# Altium schematic sheet pyBase.SchDoc(pyBase)
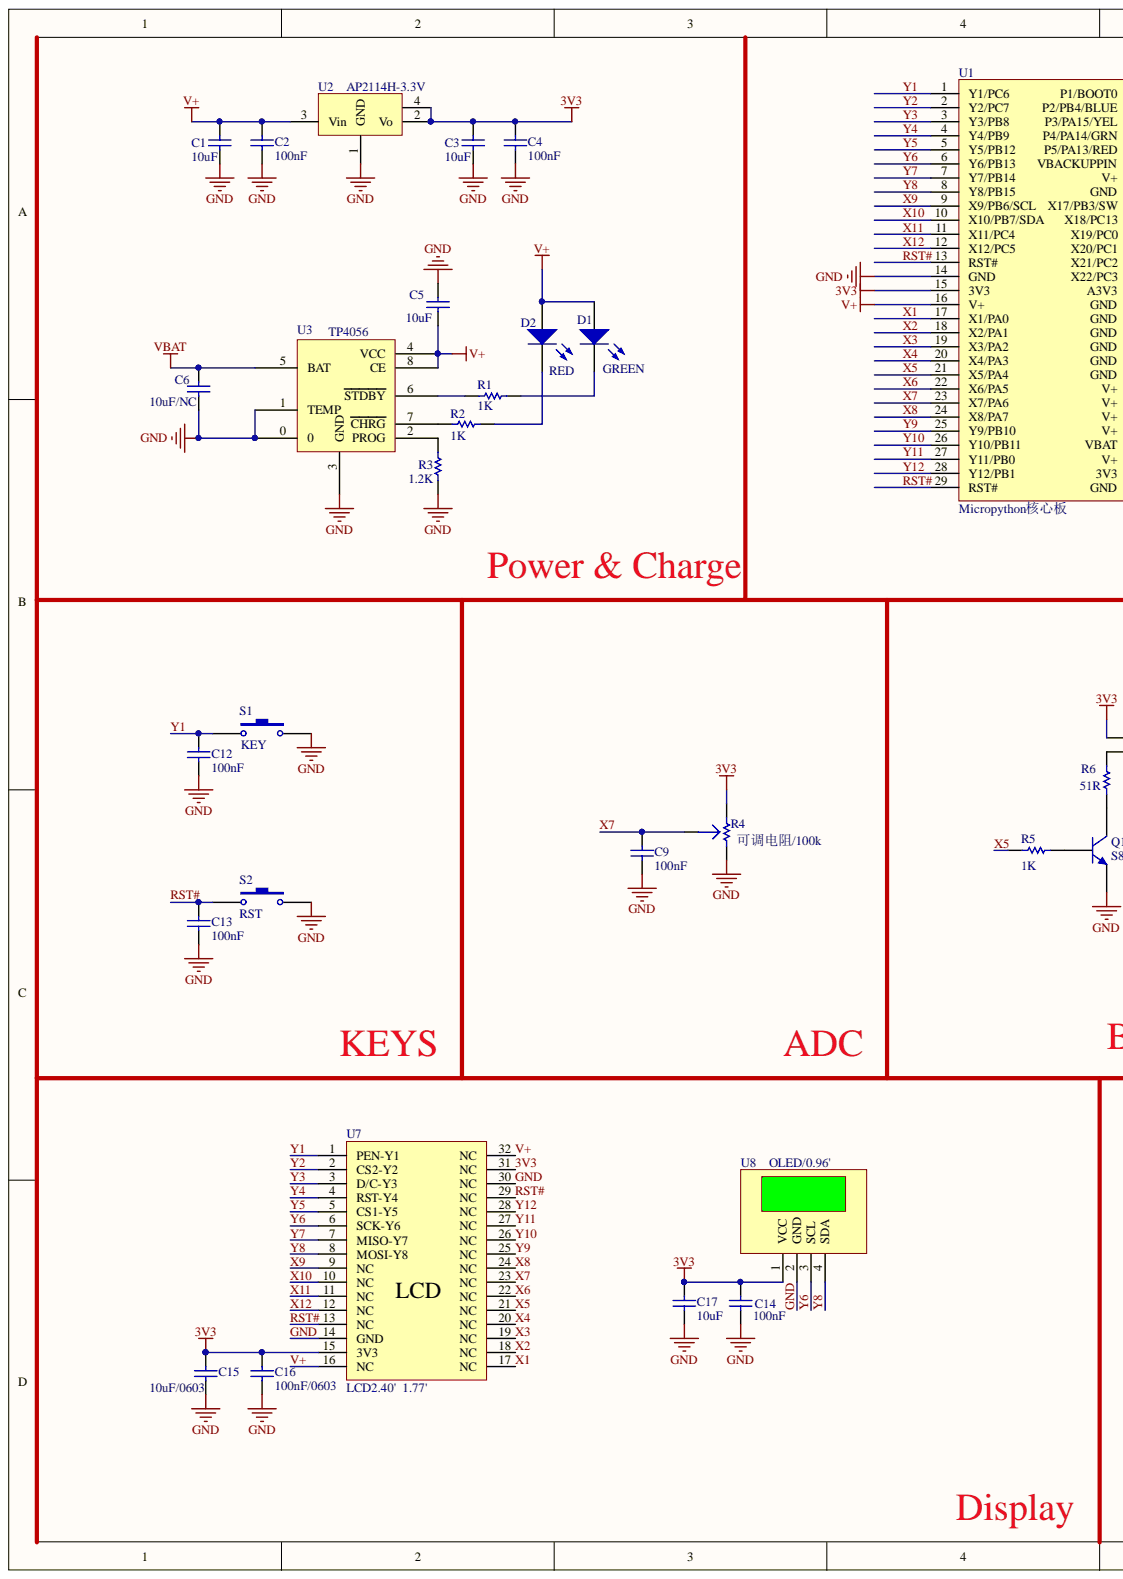
[diagram p0: pyBase.SchDoc, left]
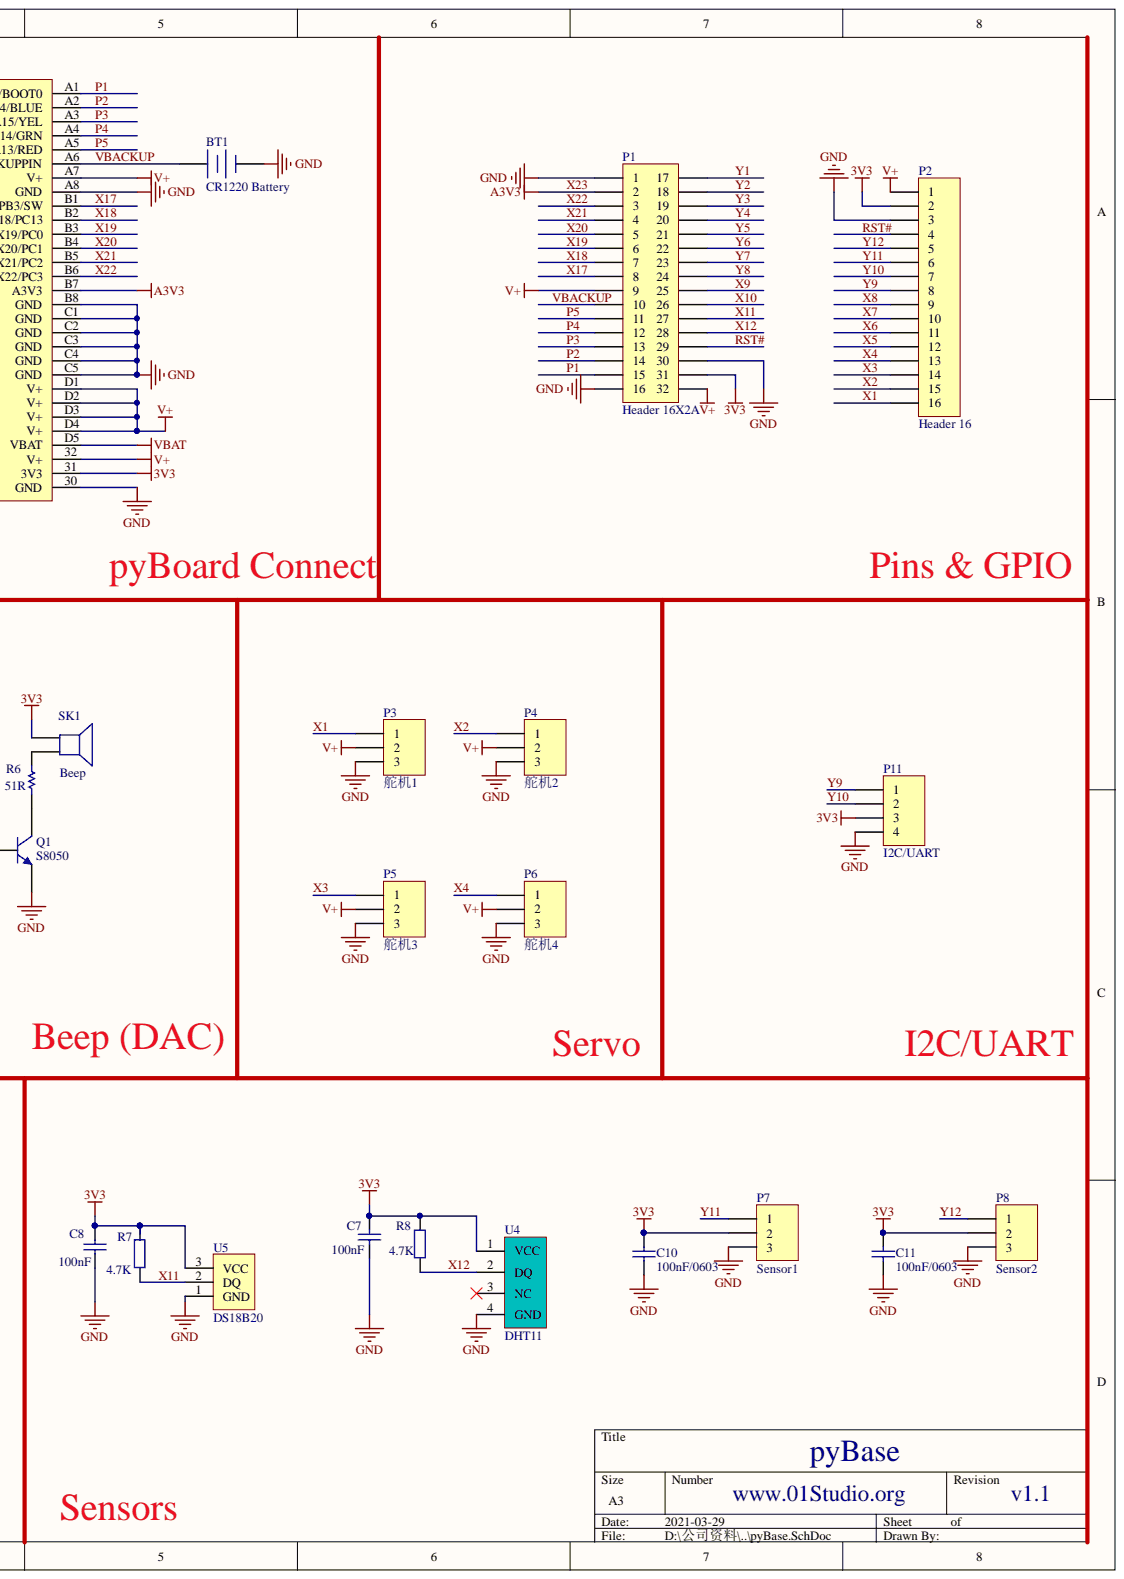
[diagram p1: pyBase.SchDoc, right]

## Parts
BT1  CR1220 Battery  [CR1220贴片电池座]
C1  10uF  [C0603]
C2  100nF  [C0603]
C3  10uF  [C0603]
C4  100nF  [C0603]
C5  10uF  [C0603]
C6  10uF/NC  [C0603]
C7  100nF  [C0603]
C8  100nF  [C0603]
C9  100nF  [C0603]
C10  100nF/0603  [C0603]
C11  100nF/0603  [C0603]
C12  100nF  [C0603]
C13  100nF  [C0603]
C14  100nF  [C0603]
C15  10uF/0603  [C0603]
C16  100nF/0603  [C0603]
C17  10uF  [C0603]
D1  GREEN  [D0603]
D2  RED  [D0603]
P1  Header 16X2A  [HDR2X16_CEN]
P2  Header 16  [HDR1X16]
P3  舵机1  [HDR1X3]
P4  舵机2  [HDR1X3]
P5  舵机3  [HDR1X3]
P6  舵机4  [HDR1X3]
P7  Sensor1  [XH2.54-3P-SMT]
P8  Sensor2  [XH2.54-3P-SMT]
P11  I2C/UART  [XH2.54-4P_SMT]
Q1  S8050  [SOT-23]
R1  1K  [R0603]
R2  1K  [R0603]
R3  1.2K  [R0603]
R4  可调电阻/100k  [电位器]
R5  1K  [R0603]
R6  51R  [R0603]
R7  value 4.7K  [R0603]
R8  value 4.7K  [R0603]
S1  KEY  [贴片大按键]
S2  RST  [贴片大按键]
SK1  Beep  [蜂鸣器9042]
U1  Micropython核心板  [MicroPython核心板]
U2  AP2114H-3.3V  [AMS1117]
U3  TP4056  [TP4056]
U4  DHT11  [DHT11-直立]
U5  DS18B20  [DS18B20]
U7  LCD2.40'  1.77'  [LCD 2.4‘ MicroPython]
U8  OLED/0.96'  [OLED 0.96']

## Nets
VBAT: C6.1 U1.D5(VBAT) U3.5(BAT)
3V3: C3.1 C4.2 C7.2 C8.2 C10.2 C11.2 C14.2 C15.1 C16.2 C17.1 P1.31 P2.2 P7.2 P8.2 P11.3 R4.1 R7.2 R8.2 SK1.1 U1.15(3V3) U1.31(3V3) U2.2(Vo) U2.4 U4.1(VCC) U5.3 U7.15(3V3) U7.31(NC) U8.1(VCC)
GND: BT1.2 C1.2 C2.1 C3.2 C4.1 C5.1 C6.2 C7.1 C8.1 C9.1 C10.1 C11.1 C12.1 C13.1 C14.1 C15.2 C16.1 C17.2 P1.1 P1.16 P1.30 P2.3 P3.3 P4.3 P5.3 P6.3 P7.3 P8.3 P11.4 Q1.2 R3.2 R4.3 S1.2 S2.2 U1.14(GND) U1.30(GND) U1.A8(GND) U1.B8(GND) U1.C1(GND) U1.C2(GND) U1.C3(GND) U1.C4(GND) U1.C5(GND) U2.1(GND) U3.0 U3.1(TEMP) U3.3(GND) U4.4(GND) U5.1 U7.14(GND) U7.30(NC) U8.2(GND)
P1: P1.15 U1.A1(P1/BOOT0)
P2: P1.14 U1.A2(P2/PB4/BLUE)
P3: P1.13 U1.A3(P3/PA15/YEL)
P4: P1.12 U1.A4(P4/PA14/GRN)
P5: P1.11 U1.A5(P5/PA13/RED)
RST#: C13.2 P1.29 P2.4 S2.1 U1.13(RST#) U1.29(RST#) U7.13(NC) U7.29(NC)
V+: C1.1 C2.2 C5.2 D1.1 D2.1 P1.9 P1.32 P2.1 P3.2 P4.2 P5.2 P6.2 U1.16(V+) U1.32(V+) U1.A7(V+) U1.D1(V+) U1.D2(V+) U1.D3(V+) U1.D4(V+) U2.3(Vin) U3.4(VCC) U3.8(CE) U7.16(NC) U7.32(NC)
VBACKUP: BT1.1 P1.10 U1.A6(VBACKUPPIN)
X1: P2.16 P3.1 U1.17(X1/PA0) U7.17(NC)
X2: P2.15 P4.1 U1.18(X2/PA1) U7.18(NC)
X3: P2.14 P5.1 U1.19(X3/PA2) U7.19(NC)
X4: P2.13 P6.1 U1.20(X4/PA3) U7.20(NC)
X5: P2.12 R5.1 U1.21(X5/PA4) U7.21(NC)
X6: P2.11 U1.22(X6/PA5) U7.22(NC)
X7: C9.2 P2.10 R4.2 U1.23(X7/PA6) U7.23(NC)
X8: P2.9 U1.24(X8/PA7) U7.24(NC)
X9: P1.25 U1.9(X9/PB6/SCL) U7.9(NC)
X10: P1.26 U1.10(X10/PB7/SDA) U7.10(NC)
X11: P1.27 R7.1 U1.11(X11/PC4) U5.2 U7.11(NC)
X12: P1.28 R8.1 U1.12(X12/PC5) U4.2(DQ) U7.12(NC)
X17: P1.8 U1.B1(X17/PB3/SW)
X18: P1.7 U1.B2(X18/PC13)
X19: P1.6 U1.B3(X19/PC0)
X20: P1.5 U1.B4(X20/PC1)
X21: P1.4 U1.B5(X21/PC2)
X22: P1.3 U1.B6(X22/PC3)
X23: P1.2 U1.B7(A3V3)
Y1: C12.2 P1.17 S1.1 U1.1(Y1/PC6) U7.1(PEN-Y1)
Y2: P1.18 U1.2(Y2/PC7) U7.2(CS2-Y2)
Y3: P1.19 U1.3(Y3/PB8) U7.3(D/C-Y3)
Y4: P1.20 U1.4(Y4/PB9) U7.4(RST-Y4)
Y5: P1.21 U1.5(Y5/PB12) U7.5(CS1-Y5)
Y6: P1.22 U1.6(Y6/PB13) U7.6(SCK-Y6) U8.3(SCL)
Y7: P1.23 U1.7(Y7/PB14) U7.7(MISO-Y7)
Y8: P1.24 U1.8(Y8/PB15) U7.8(MOSI-Y8) U8.4(SDA)
Y9: P2.8 P11.1 U1.25(Y9/PB10) U7.25(NC)
Y10: P2.7 P11.2 U1.26(Y10/PB11) U7.26(NC)
Y11: P2.6 P7.1 U1.27(Y11/PB0) U7.27(NC)
Y12: P2.5 P8.1 U1.28(Y12/PB1) U7.28(NC)
NetD1_2: D1.2 R1.1
NetD2_2: D2.2 R2.1
NetQ1_1: Q1.1 R5.2
NetQ1_3: Q1.3 R6.1
NetR1_2: R1.2 U3.6(STDBY)
NetR2_2: R2.2 U3.7(CHRG)
NetR3_1: R3.1 U3.2(PROG)
NetR6_2: R6.2 SK1.2

Not connected: U4 3(NC)

## Library data of the parts
BT1 = Battery: Library Name: WeBee.SCHLIB; Component Kind: Standard
C1 C3 C5 C6 C15 C17 = Cap Semi: Capacitor (Semiconductor SIM Model); Simulation: CAP; Signal Integrity: Cap Semi; Published: 8-Jun-2000; PackageReference: C1206; Library Name: Miscellaneous Devices.IntLib; LatestRevisionNote: Re-released for DXP Platform.; LatestRevisionDate: 17-Jul-2002; Component Kind: Standard; Publisher: Altium Limited
C2 C4 C7-C14 C16 = Cap: Capacitor; Value: 100pF; Simulation: CAP; Signal Integrity: Cap; Published: 8-Jun-2000; PackageReference: RAD-0.3; Library Name: Miscellaneous Devices.IntLib; LatestRevisionNote: Re-released for DXP Platform.; LatestRevisionDate: 17-Jul-2002; Component Kind: Standard; Publisher: Altium Limited
D1 D2 = LED0: Typical INFRARED GaAs LED; Simulation: LED0; Published: 8-Jun-2000; PackageReference: LED-0; Library Name: Miscellaneous Devices.IntLib; LatestRevisionNote: Mech Layer 1 removed.; LatestRevisionDate: 08-Jul-2005; Component Kind: Standard; Publisher: Altium Limited
P1 = Header 16X2A: Header, 16-Pin, Dual row; Signal Integrity: Connector; Library Name: Miscellaneous Connectors.IntLib; LatestRevisionNote: Re-released for DXP Platform.; LatestRevisionDate: 17-Jul-2002; Component Kind: Standard; Publisher: Altium Limited
P2 = Header 16: Header, 16-Pin; Signal Integrity: Connector; Library Name: Miscellaneous Connectors.IntLib; LatestRevisionNote: Re-released for DXP Platform.; LatestRevisionDate: 17-Jul-2002; Component Kind: Standard; Publisher: Altium Limited
P3-P8 = Header 3: Header, 3-Pin; Signal Integrity: Connector; Library Name: Miscellaneous Connectors.IntLib; LatestRevisionNote: Re-released for DXP Platform.; LatestRevisionDate: 17-Jul-2002; Component Kind: Standard; Publisher: Altium Limited
P11 = Header 4: Header, 4-Pin; Signal Integrity: Connector; Library Name: Miscellaneous Connectors.IntLib; LatestRevisionNote: Re-released for DXP Platform.; LatestRevisionDate: 17-Jul-2002; Component Kind: Standard; Publisher: Altium Limited
R1-R3 R5 = Res1: Resistor; Simulation: RESISTOR; Signal Integrity: Res; Published: 8-Jun-2000; PackageReference: AXIAL-0.3; Library Name: Miscellaneous Devices.IntLib; LatestRevisionNote: Re-released for DXP Platform.; LatestRevisionDate: 17-Jul-2002; Component Kind: Standard; Publisher: Altium Limited
  R5: Value: 1K
R4 = 电位器: Library Name: WeBee.SCHLIB; Component Kind: Standard
R6 = Res1: Resistor; Value: 1K; Simulation: RESISTOR; Signal Integrity: Res; Published: 8-Jun-2000; PackageReference: AXIAL-0.3; Library Name: Miscellaneous Devices.IntLib; LatestRevisionNote: Re-released for DXP Platform.; LatestRevisionDate: 17-Jul-2002; Component Kind: Standard; Publisher: Altium Limited
R7 R8 = Res2: Resistor; Simulation: RESISTOR; Signal Integrity: Res; Published: 8-Jun-2000; PackageReference: AXIAL-0.4; Library Name: Miscellaneous Devices.IntLib; LatestRevisionNote: Re-released for DXP Platform.; LatestRevisionDate: 17-Jul-2002; Component Kind: Standard; Publisher: Altium Limited
S1 S2 = SW-PB: Switch; Published: 24-Mar-1999; PackageReference: SPST-2; Library Name: Miscellaneous Devices.IntLib; LatestRevisionNote: Re-released for DXP Platform.; LatestRevisionDate: 17-Jul-2002; Component Kind: Standard; Publisher: Altium Limited
SK1 = Beeper: Library Name: WeBee.SCHLIB; Component Kind: Standard
U2 = AMS1117: Library Name: WeBee.SCHLIB; Component Kind: Standard
U3 = PJ5056: Component Kind: Standard
U7 = LCD1.77' 2.4' MicroPython: Library Name: WeBee.SCHLIB; Component Kind: Standard
U8 = OLED 0.96': Library Name: WeBee.SCHLIB; Component Kind: Standard
Q1 = S8050: Library Name: WeBee.SCHLIB; Component Kind: Standard
U1 = MicroPython核心板: Library Name: WeBee.SCHLIB; Component Kind: Standard
U4 = DHT11: Library Name: WeBee.SCHLIB; Component Kind: Standard
U5 = DS18B20: Library Name: WeBee.SCHLIB; Component Kind: Standard
